# Altium schematic sheet MCU_D_070R 1V3.SchDoc("MCU_D_070R 1V3")
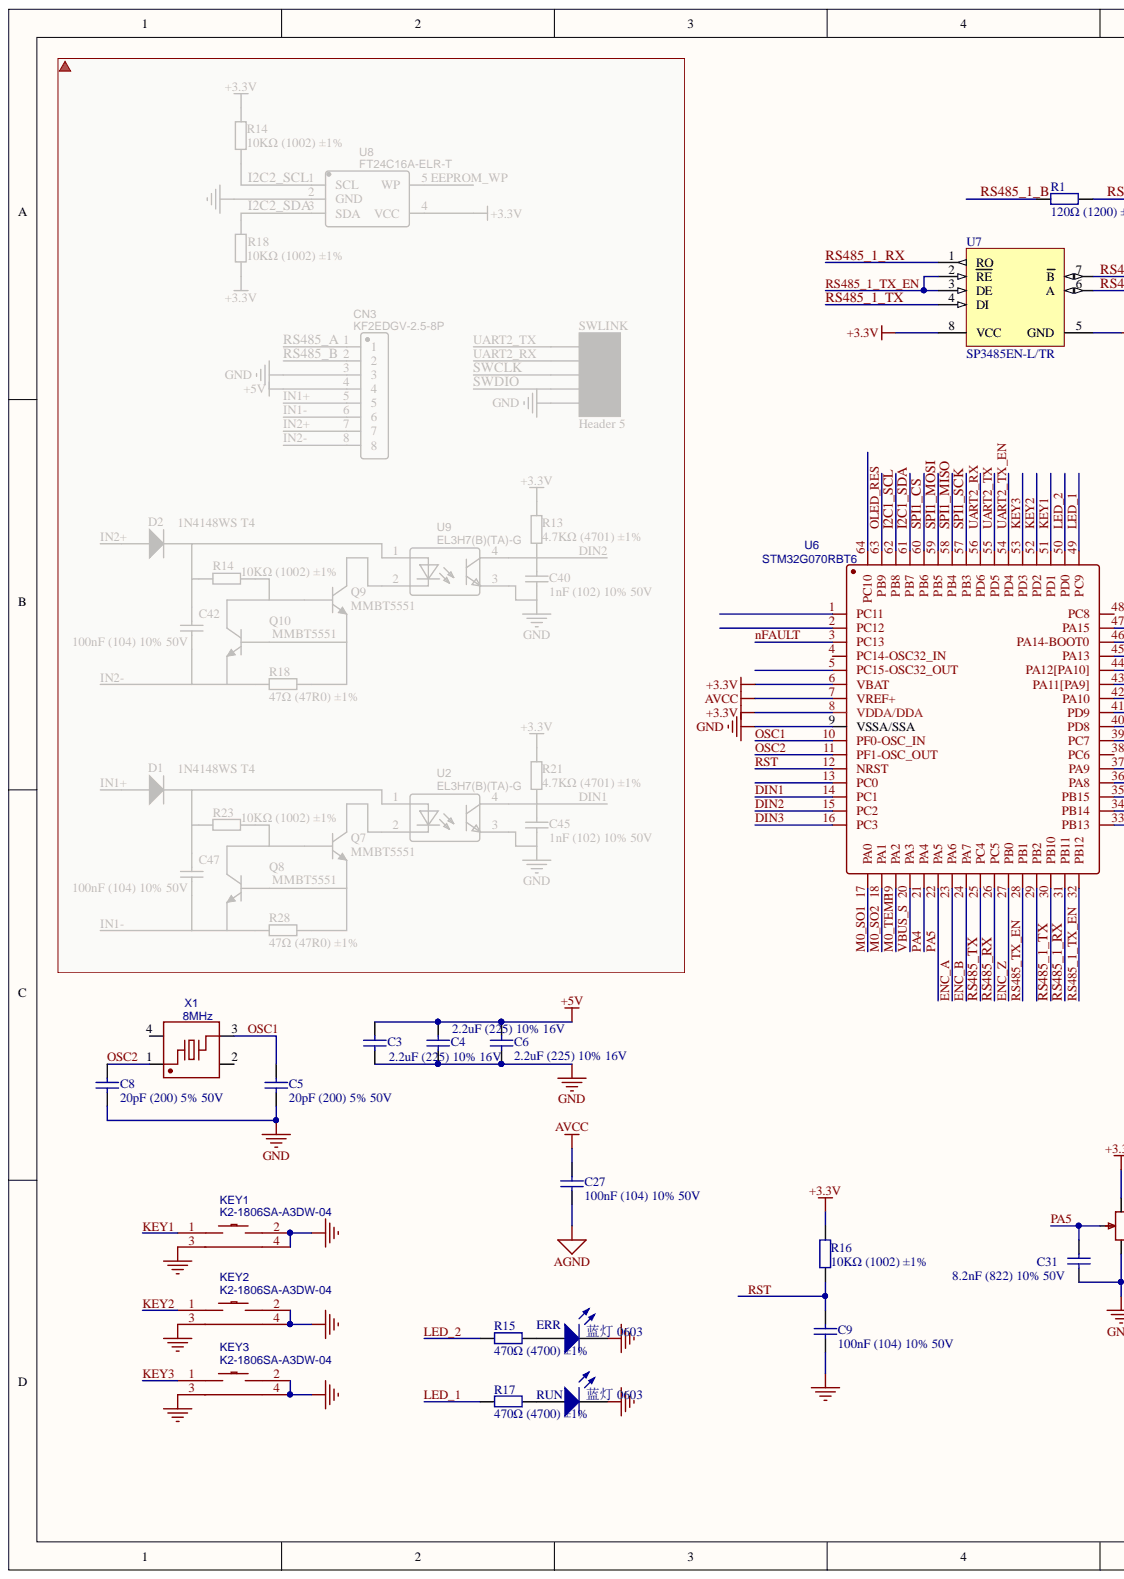
[diagram p0: MCU_D_070R 1V3.SchDoc, left]
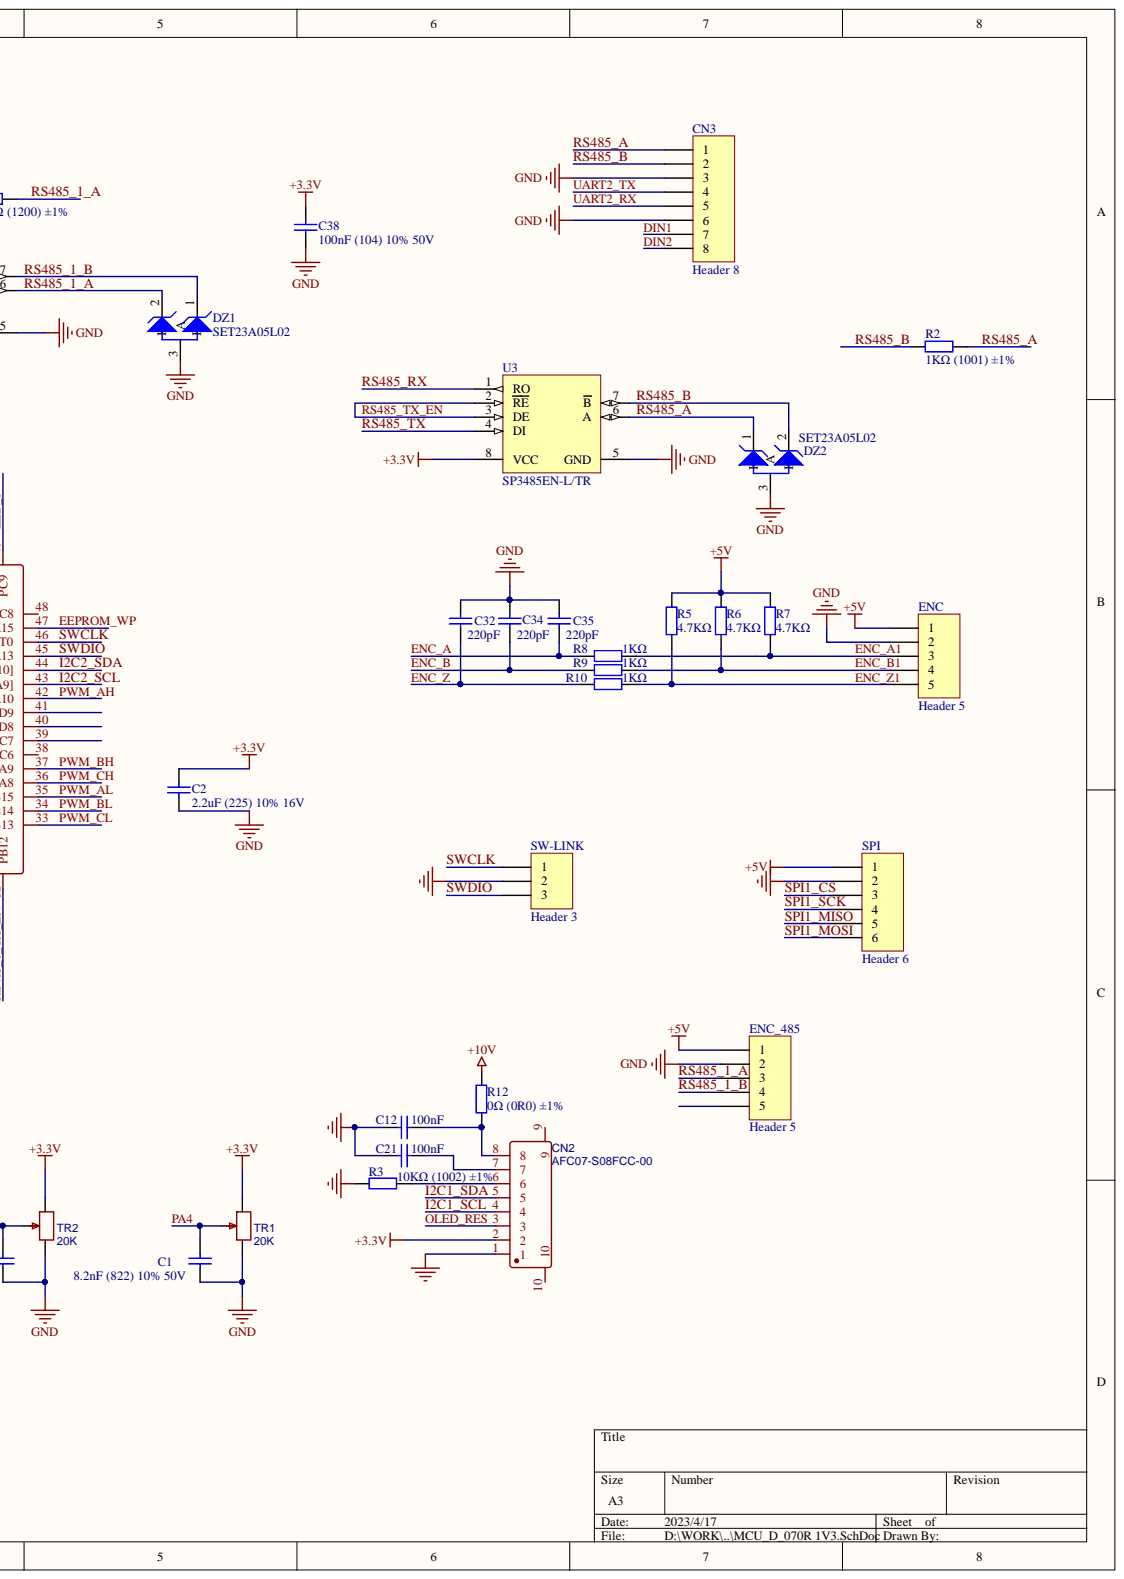
[diagram p1: MCU_D_070R 1V3.SchDoc, right]

## Parts
C1  8.2nF (822) 10% 50V  [0603_C]
C2  2.2uF (225) 10% 16V  [0603_C]
C3  2.2uF (225) 10% 16V  [0603_C]
C4  2.2uF (225) 10% 16V  [0603_C]
C5  20pF (200) 5% 50V  [0603_C]
C6  2.2uF (225) 10% 16V  [0603_C]
C8  20pF (200) 5% 50V  [0603_C]
C9  100nF (104) 10% 50V  [0603_C]
C12  100nF  [0603_C]
C21  100nF  [0603_C]
C27  100nF (104) 10% 50V  [0603_C]
C31  8.2nF (822) 10% 50V  [0603_C]
C32  220pF  [0603_C]
C34  220pF  [0603_C]
C35  220pF  [0603_C]
C38  100nF (104) 10% 50V  [0603_C]
CN2  AFC07-S08FCC-00  [AFC07-S08FCC-00]
CN3  Header 8  [XH2.54-LI-8P]
DZ1  SET23A05L02  [SOT-23(SOT-23-3)]
DZ2  SET23A05L02  [SOT-23(SOT-23-3)]
ENC  Header 5  [XH2.54-LI-5P]
ENC_485  Header 5  [GH 1.25 -5p-90]
ERR  蓝灯 0603  Package: 0603  [0603_LED_S1]
KEY1  K2-1806SA-A3DW-04  [KEY-SMD_K2-1806SA-AXXW-XX]
KEY2  K2-1806SA-A3DW-04  [KEY-SMD_K2-1806SA-AXXW-XX]
KEY3  K2-1806SA-A3DW-04  [KEY-SMD_K2-1806SA-AXXW-XX]
R1  120Ω (1200) ±1%  [0805_R]
R2  1KΩ (1001) ±1%  [0805_R]
R3  10KΩ (1002) ±1%  [0603_R]
R5  4.7KΩ  [0603_R]
R6  4.7KΩ  [0603_R]
R7  4.7KΩ  [0603_R]
R8  1KΩ  [0603_R]
R9  1KΩ  [0603_R]
R10  1KΩ  [0603_R]
R12  0Ω (0R0) ±1%  [0603_R]
R15  470Ω (4700) ±1%  [0603_R]
R16  10KΩ (1002) ±1%  [0603_R]
R17  470Ω (4700) ±1%  [0603_R]
RUN  蓝灯 0603  Package: 0603  [0603_LED_S1]
SPI  Header 6  [HDR1X6]
SW-LINK  Header 3  [HDR1X3]
TR1  20K  [3303W]
TR2  20K  [3303W]
U3  SP3485EN-L/TR  [SOP-8_150mil]
U6  STM32G070RBT6  [LQFP64_N]
U7  SP3485EN-L/TR  [SOP-8_150mil]
X1  8MHz  [OSC-3225-4P]

## Nets
+3.3V: C2.2 C38.2 CN2.2 R16.2 TR1.3 TR2.3 U3.8(VCC) U6.6(VBAT) U6.8(VDDA/DDA) U7.8(VCC)
+5V: C3.2 C4.2 C6.2 ENC.1 ENC_485.1 R5.2 R6.2 R7.2 SPI.1
+10V: R12.2
AGND: C27.1
AVCC: C27.2 U6.7(VREF+)
GND: C1.1 C2.1 C3.1 C4.1 C5.1 C6.1 C8.1 C9.1 C12.2 C21.2 C31.1 C32.2 C34.2 C35.2 C38.1 CN2.1 CN3.3 CN3.6 DZ1.3 DZ2.3 ENC.2 ENC_485.2 ERR.K KEY1.2 KEY1.3 KEY1.4 KEY2.2 KEY2.3 KEY2.4 KEY3.2 KEY3.3 KEY3.4 R3.2 RUN.K SPI.2 SW-LINK.2 TR1.1 TR2.1 U3.5(GND) U6.9(VSSA/SSA) U7.5(GND)
DIN1: CN3.7 U6.14(PC1)
DIN2: CN3.8 U6.15(PC2)
DIN3: U6.16(PC3)
EEPROM_WP: U6.47(PA15)
ENC_A: C35.1 R8.1 U6.23(PA6)
ENC_A1: ENC.3 R7.1 R8.2
ENC_B: C34.1 R9.1 U6.24(PA7)
ENC_B1: ENC.4 R6.1 R9.2
ENC_Z: C32.1 R10.1 U6.27(PB0)
ENC_Z1: ENC.5 R5.1 R10.2
I2C1_SCL: CN2.4 U6.62(PB8)
I2C1_SDA: CN2.5 U6.61(PB7)
I2C2_SCL: U6.43(PA11[PA9])
I2C2_SDA: U6.44(PA12[PA10])
KEY1: KEY1.1 U6.51(PD1)
KEY2: KEY2.1 U6.52(PD2)
KEY3: KEY3.1 U6.53(PD3)
LED_1: R17.1 U6.49(PC9)
LED_2: R15.1 U6.50(PD0)
M0_SO1: U6.17(PA0)
M0_SO2: U6.18(PA1)
M0_TEMP: U6.19(PA2)
NFAULT: U6.3(PC13)
OLED_RES: CN2.3 U6.63(PB9)
OSC1: C5.2 U6.10(PF0-OSC_IN) X1.3
OSC2: C8.2 U6.11(PF1-OSC_OUT) X1.1
PA4: C1.2 TR1.2 U6.21(PA4)
PA5: C31.2 TR2.2 U6.22(PA5)
PWM_AH: U6.42(PA10)
PWM_AL: U6.35(PB15)
PWM_BH: U6.37(PA9)
PWM_BL: U6.34(PB14)
PWM_CH: U6.36(PA8)
PWM_CL: U6.33(PB13)
RS485_1_A: DZ1.2 ENC_485.3 R1.2 U7.6(A)
RS485_1_B: DZ1.1 ENC_485.4 R1.1 U7.7(B)
RS485_1_RX: U6.31(PB11) U7.1(RO)
RS485_1_TX: U6.30(PB10) U7.4(DI)
RS485_1_TX_EN: U6.32(PB12) U7.2(RE) U7.3(DE)
RS485_A: CN3.1 DZ2.1 R2.2 U3.6(A)
RS485_B: CN3.2 DZ2.2 R2.1 U3.7(B)
RS485_RX: U3.1(RO) U6.26(PC5)
RS485_TX: U3.4(DI) U6.25(PC4)
RS485_TX_EN: U3.2(RE) U3.3(DE) U6.28(PB1)
RST: C9.2 R16.1 U6.12(NRST)
SPI1_CS: SPI.3 U6.60(PB6)
SPI1_MISO: SPI.5 U6.58(PB4)
SPI1_MOSI: SPI.6 U6.59(PB5)
SPI1_SCK: SPI.4 U6.57(PB3)
SWCLK: SW-LINK.1 U6.46(PA14-BOOT0)
SWDIO: SW-LINK.3 U6.45(PA13)
UART2_RX: CN3.5 U6.56(PD6)
UART2_TX: CN3.4 U6.55(PD5)
UART2_TX_EN: U6.54(PD4)
VBUS_S: U6.20(PA3)
NetC12_1: C12.1 CN2.8 R12.1
NetC21_1: C21.1 CN2.7
NetCN2_6: CN2.6 R3.1
NetERR_A: ERR.A R15.2
NetR17_2: R17.2 RUN.A

Not connected: CN2 9 10; ENC_485 5; U6 1(PC11) 2(PC12) 4(PC14-OSC32_IN) 5(PC15-OSC32_OUT) 13(PC0) 29(PB2) 38(PC6) 39(PC7) 40(PD8) 41(PD9) 48(PC8) 64(PC10); X1 2 4

## Library data of the parts
C1 C31 = 0603 8.2nF (822) 10% 50V: 贴片电容; Component Kind: Standard; ComponentLink1Description: 供应商链接; ComponentLink1URL: http://www.szlcsc.com/product/details_28670.html; Library Name: Miscellaneous Devices LC.IntLib; Package: 0603; Supplier: LC; SuppliersPartNumber: C27920
C2-C4 C6 = 0603 2.2uF (225) 10% 16V: 贴片电容; Component Kind: Standard; ComponentLink1Description: 供应商链接; ComponentLink1URL: http://www.szlcsc.com/product/details_24366.html; Library Name: Cap.SchLib; Package: 0603; Supplier: LC; SuppliersPartNumber: C23630
C5 C8 = 0603 20pF (200) 5% 50V: 贴片电容; Component Kind: Standard; ComponentLink1Description: 供应商链接; ComponentLink1URL: http://www.szlcsc.com/product/details_2000.html; Library Name: Cap.SchLib; Package: 0603; Supplier: LC; SuppliersPartNumber: C1648
C9 C12 C21 C27 C38 = 0603 100nF (104) 10% 50V: 贴片电容; Component Kind: Standard; ComponentLink1Description: 供应商链接; ComponentLink1URL: http://www.szlcsc.com/product/details_15331.html; Library Name: Cap.SchLib; Package: 0603; Supplier: LC; SuppliersPartNumber: C14663
C32 C34 C35 = 0603 220pF (221) 10% 50V: 贴片电容; Component Kind: Standard; ComponentLink1Description: 供应商链接; ComponentLink1URL: http://www.szlcsc.com/product/details_1955.html; Library Name: Cap.SchLib; Package: 0603; Supplier: LC; SuppliersPartNumber: C1603
CN2 = KH-CL0.5-H2.0-8PS: Contributor: LCSC; BOM_Manufacturer Part: AFC07-S08FCC-00; BOM_Supplier: LCSC; BOM_Supplier Part: C262202; Component Kind: Standard; spicePre: C; spiceSymbolName: AFC07-S08FCC-00
CN3 = Header 8: Header, 8-Pin; Component Kind: Standard; LatestRevisionDate: 17-Jul-2002; LatestRevisionNote: Re-released for DXP Platform.; Library Name: Miscellaneous Connectors.IntLib; Signal Integrity: Connector; Publisher: Altium Limited
DZ1 DZ2 = PSOT15C: ESD二极管 5V,/5uA/SOT-23 Brightking SET23A05L02; LH: 7.2.05.0092; U: DZ; Component Kind: Standard; Library Name: 2M880C_1.SCHLIB; Manufactor Part Number: SET23A05L02; Signal Integrity: D Zener; Simulation: ZENER
ENC ENC_485 = Header 5: Header, 5-Pin; Component Kind: Standard; LatestRevisionDate: 17-Jul-2002; LatestRevisionNote: Re-released for DXP Platform.; Library Name: Miscellaneous Connectors.IntLib; Signal Integrity: Connector; Publisher: Altium Limited
ERR RUN = 0603 蓝灯: 发光二极管; Component Kind: Standard; ComponentLink1Description: 供应商链接; ComponentLink1URL: http://www.szlcsc.com/product/details_73150.html; Library Name: LED.SchLib; Supplier: LC; SuppliersPartNumber: C72041
KEY1-KEY3 = K2-1806SA-A3DW-04: BOM_Manufacturer: 韩国韩荣; Contributor: LCSC; BOM_JLCPCB Part Class: Extended Part; BOM_Manufacturer Part: K2-1806SA-A3DW-04; BOM_Supplier: LCSC; BOM_Supplier Part: C2690015; Component Kind: Standard; spicePre: S; spiceSymbolName: K2-1806SA-A3DW-04
R1 = 0805 120Ω (1200) 1%: 贴片电阻; Component Kind: Standard; ComponentLink1Description: 供应商链接; ComponentLink1URL: http://www.szlcsc.com/product/details_18125.html; Library Name: RES.SchLib; Package: 0805; Supplier: LC; SuppliersPartNumber: C17437
R2 = 0805 1KΩ (1001) 1%: 贴片电阻; Component Kind: Standard; ComponentLink1Description: 供应商链接; ComponentLink1URL: http://www.szlcsc.com/product/details_18201.html; Library Name: Miscellaneous Devices LC.IntLib; Package: 0805; Supplier: LC; SuppliersPartNumber: C17513
R3 R16 = 0603 10KΩ (1002) 1%: 贴片电阻; Component Kind: Standard; ComponentLink1Description: 供应商链接; ComponentLink1URL: http://www.szlcsc.com/product/details_26547.html; Library Name: RES.SchLib; Package: 0603; Supplier: LC; SuppliersPartNumber: C25804
R5-R7 = 0603 4.7KΩ (4701) 1%: 贴片电阻; Component Kind: Standard; ComponentLink1Description: 供应商链接; ComponentLink1URL: http://www.szlcsc.com/product/details_23889.html; Library Name: Miscellaneous Devices LC.IntLib; Package: 0603; Supplier: LC; SuppliersPartNumber: C23162
R8-R10 = 0603 1KΩ (1001) 1%: 贴片电阻; Component Kind: Standard; ComponentLink1Description: 供应商链接; ComponentLink1URL: http://www.szlcsc.com/product/details_21904.html; Library Name: Miscellaneous Devices LC.IntLib; Package: 0603; Supplier: LC; SuppliersPartNumber: C21190
R12 = 0603 0Ω (0R0) 1%: 贴片电阻; Component Kind: Standard; ComponentLink1Description: 供应商链接; ComponentLink1URL: http://www.szlcsc.com/product/details_21903.html; Library Name: Miscellaneous Devices LC.IntLib; Package: 0603; Supplier: LC; SuppliersPartNumber: C21189
R15 R17 = 0603 470Ω (4700) 1%: 贴片电阻; Component Kind: Standard; ComponentLink1Description: 供应商链接; ComponentLink1URL: http://www.szlcsc.com/product/details_23906.html; Library Name: RES.SchLib; Package: 0603; Supplier: LC; SuppliersPartNumber: C23179
SPI = Header 6: Header, 6-Pin; Component Kind: Standard; LatestRevisionDate: 17-Jul-2002; LatestRevisionNote: Re-released for DXP Platform.; Library Name: Miscellaneous Connectors.IntLib; Signal Integrity: Connector; Publisher: Altium Limited
SW-LINK = Header 3: Header, 3-Pin; Component Kind: Standard; LatestRevisionDate: 17-Jul-2002; LatestRevisionNote: Re-released for DXP Platform.; Library Name: Miscellaneous Connectors.IntLib; Signal Integrity: Connector; Publisher: Altium Limited
TR1 TR2 = 20K: BOM_Manufacturer: HDK; BOM_Manufacturer Part: VG039NCHXTB203; nameAlias: Resistance (Ohms); BOM_JLCPCB Part Class: Extended Part; BOM_Supplier: LCSC; BOM_Supplier Part: C128550; Component Kind: Standard; Resistance(Ohms): 20K; spicePre: R; spiceSymbolName: VG039NCHXTB203
U3 U7 = SP3485EN-L/TR_[C8963]: RS-485/RS-422; Component Kind: Standard; ComponentLink1Description: 供应商链接; ComponentLink1URL: http://www.szlcsc.com/product/details_9482.html; Library Name: IC.SchLib; Package: SOIC-8; Supplier: LC; SuppliersPartNumber: C8963
U6 = STM32G070RBT6: BOM_Manufacturer: STMicroelectronics; Contributor: LCSC; BOM_JLCPCB Part Class: Extended Part; BOM_Manufacturer Part: STM32G070RBT6; BOM_Supplier: LCSC; BOM_Supplier Part: C529340; Component Kind: Standard; spicePre: U; spiceSymbolName: STM32G070RBT6
X1 = 8MHz: BOM_Manufacturer: YXC扬兴科技; BOM_Manufacturer Part: X32258MSB4SI; Contributor: LCSC; nameAlias: Frequency; BOM_JLCPCB Part Class: Extended Part; BOM_Supplier: LCSC; BOM_Supplier Part: C2682774; Component Kind: Standard; spicePre: X; spiceSymbolName: X32258MSB4SI
